# Altium schematic sheet TP_STM32_EXT.SchDoc
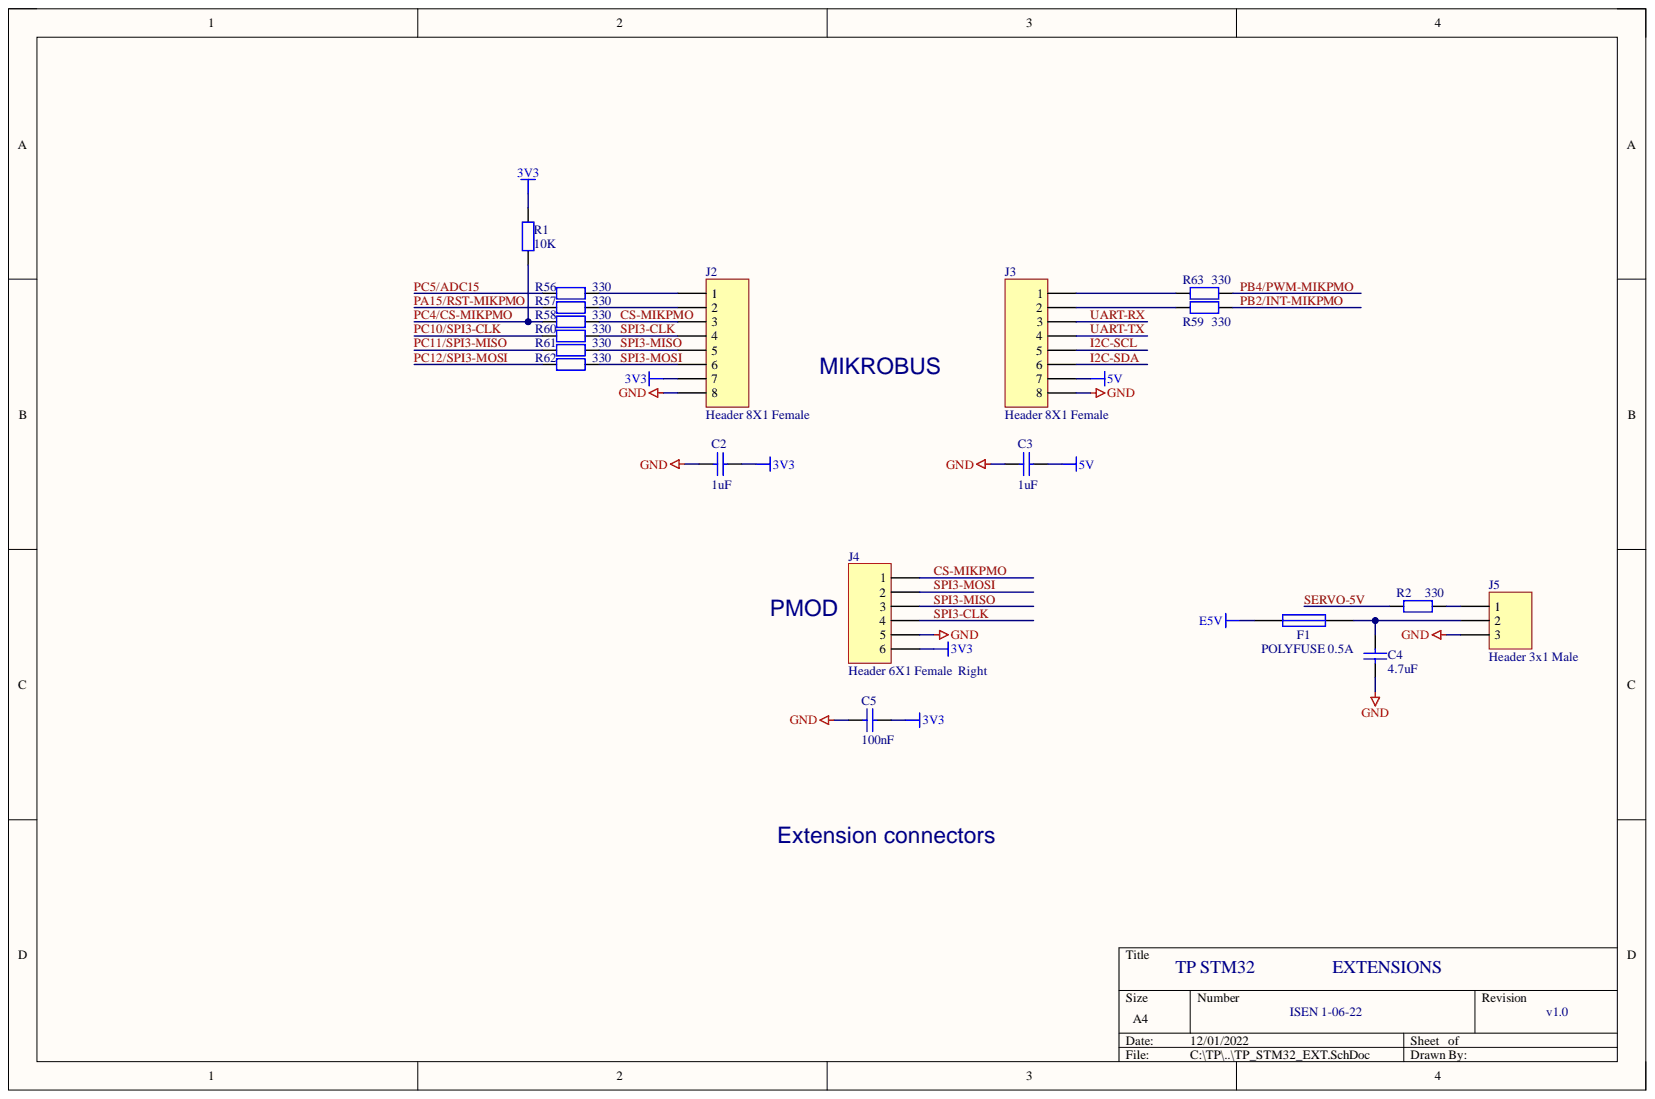
[diagram p0: TP_STM32_EXT.SchDoc]

## Parts
C2  1uF  [CC2012-0805]
C3  1uF  [CC2012-0805]
C4  4.7uF  [CC2012-0805]
C5  100nF  [CC2012-0805]
F1  POLYFUSE 0.5A  [POLYFUSE_1206]
J2  Header 8X1 Female  [HE14-1X8F]
J3  Header 8X1 Female  [HE14-1X8F]
J4  Header 6X1 Female  Right  [HE14-1X6-FEM-RIGHT]
J5  Header 3x1 Male  [HE14-1X3M]
R1  10K  [R2012-0805]
R2  330  [R2012-0805]
R56  330  [R2012-0805]
R57  330  [R2012-0805]
R58  330  [R2012-0805]
R59  330  [R2012-0805]
R60  330  [R2012-0805]
R61  330  [R2012-0805]
R62  330  [R2012-0805]
R63  330  [R2012-0805]

## Nets
3V3: C2.1 C5.1 J2.7 J4.6 R1.2
5V: C3.1 J3.7
E5V: F1.1
GND: C2.2 C3.2 C4.2 C5.2 J2.8 J3.8 J4.5 J5.3
CS-MIKPMO: J2.3 J4.1 R58.2
I2C-SCL: J3.5
I2C-SDA: J3.6
PA15/RST-MIKPMO: R57.1
PB2/INT-MIKPMO: R59.2
PB4/PWM-MIKPMO: R63.2
PC4/CS-MIKPMO: R1.1 R58.1
PC5/ADC15: R56.1
PC10/SPI3-CLK: R60.1
PC11/SPI3-MISO: R61.1
PC12/SPI3-MOSI: R62.1
SERVO-5V: R2.1
SPI3-CLK: J2.4 J4.4 R60.2
SPI3-MISO: J2.5 J4.3 R61.2
SPI3-MOSI: J2.6 J4.2 R62.2
UART-RX: J3.3
UART-TX: J3.4
NetC4_1: C4.1 F1.2 J5.2
NetJ2_1: J2.1 R56.2
NetJ2_2: J2.2 R57.2
NetJ3_1: J3.1 R63.1
NetJ3_2: J3.2 R59.1
NetJ5_1: J5.1 R2.2

## Library data of the parts
C2 C3 = Cap: Capacitor MLCC X7R 25V; Manufacturer: Generic Components; Class I: Passive; Class II: Capacitor; Component Kind: Standard; Library Name: Miscellaneous Devices.IntLib; Published: 8-Jun-2000; Revision: July-2002: Re-released for DXP Platform.; Signal Integrity: Cap; Simulation: CAP; Value: 1uF; Publisher: Altium Limited
C4 = Cap: Capacitor MLCC X5R 10V; Manufacturer: Generic Components; Class I: Passive; Class II: Capacitor; Component Kind: Standard; Library Name: Miscellaneous Devices.IntLib; Published: 8-Jun-2000; Revision: July-2002: Re-released for DXP Platform.; Signal Integrity: Cap; Simulation: CAP; Value: 4.7uF; Publisher: Altium Limited
C5 = Cap: Capacitor MLCC X7R 50V; Manufacturer: Generic Components; Class I: Passive; Class II: Capacitor; Component Kind: Standard; Library Name: Miscellaneous Devices.IntLib; Published: 8-Jun-2000; Revision: July-2002: Re-released for DXP Platform.; Signal Integrity: Cap; Simulation: CAP; Value: 100nF; Publisher: Altium Limited
F1 = Fuse 1: Fuse resettable 0.5A SMD; Manufacturer: LITTELFUSE; PartNumber: 1206L050/15 YR; Component Kind: Standard; LatestRevisionDate: 17-Jul-2002; LatestRevisionNote: Re-released for DXP Platform.; Library Name: Miscellaneous Devices.IntLib; PackageDescription: Thru-Hole; 2 Leads; PackageReference: PIN-W2/E2.8; Published: 8-Jun-2000; Simulation: FUSE; Publisher: Altium Limited
J2 J3 = Header 8: Header 8X1 Female 2.54mm; Manufacturer: SAMTEC; Manufacturer Part Number: SSW-108-01-T-S; Component Kind: Standard; LatestRevisionDate: 17-Jul-2002; LatestRevisionNote: Re-released for DXP Platform.; Library Name: Miscellaneous Connectors.IntLib; Signal Integrity: Connector; Publisher: Altium Limited
J4 = Header 6: Header 6X1 Female 2.54mm Right angle; Manufacturer: SAMTEC; Manufacturer Part Number: SSW-106-02-G-S-RA; Component Kind: Standard; LatestRevisionDate: 17-Jul-2002; LatestRevisionNote: Re-released for DXP Platform.; Library Name: Miscellaneous Connectors.IntLib; Signal Integrity: Connector; Publisher: Altium Limited
J5 = Header 3: Header 3X1 Male 2.54mm; Component Kind: Standard; LatestRevisionDate: 17-Jul-2002; LatestRevisionNote: Re-released for DXP Platform.; Library Name: Miscellaneous Connectors.IntLib; Signal Integrity: Connector; Publisher: Altium Limited
R1 R2 R56-R63 = Res2: Resistor; Manufacturer: Generic Components; Class I: Passive; Class II: Resistor; Component Kind: Standard; Library Name: Miscellaneous Devices.IntLib; Published: 8-Jun-2000; Revision: July-2002: Re-released for DXP Platform.; Signal Integrity: Res2; Simulation: RESISTOR; Publisher: Altium Limited
  R1: Value: 10K
  R2 R56-R63: Value: 330
